# Altium schematic sheet Power Converter 12V as TO-3 replacement.SchDoc("Power Converter 12V as TO-3 replacement")
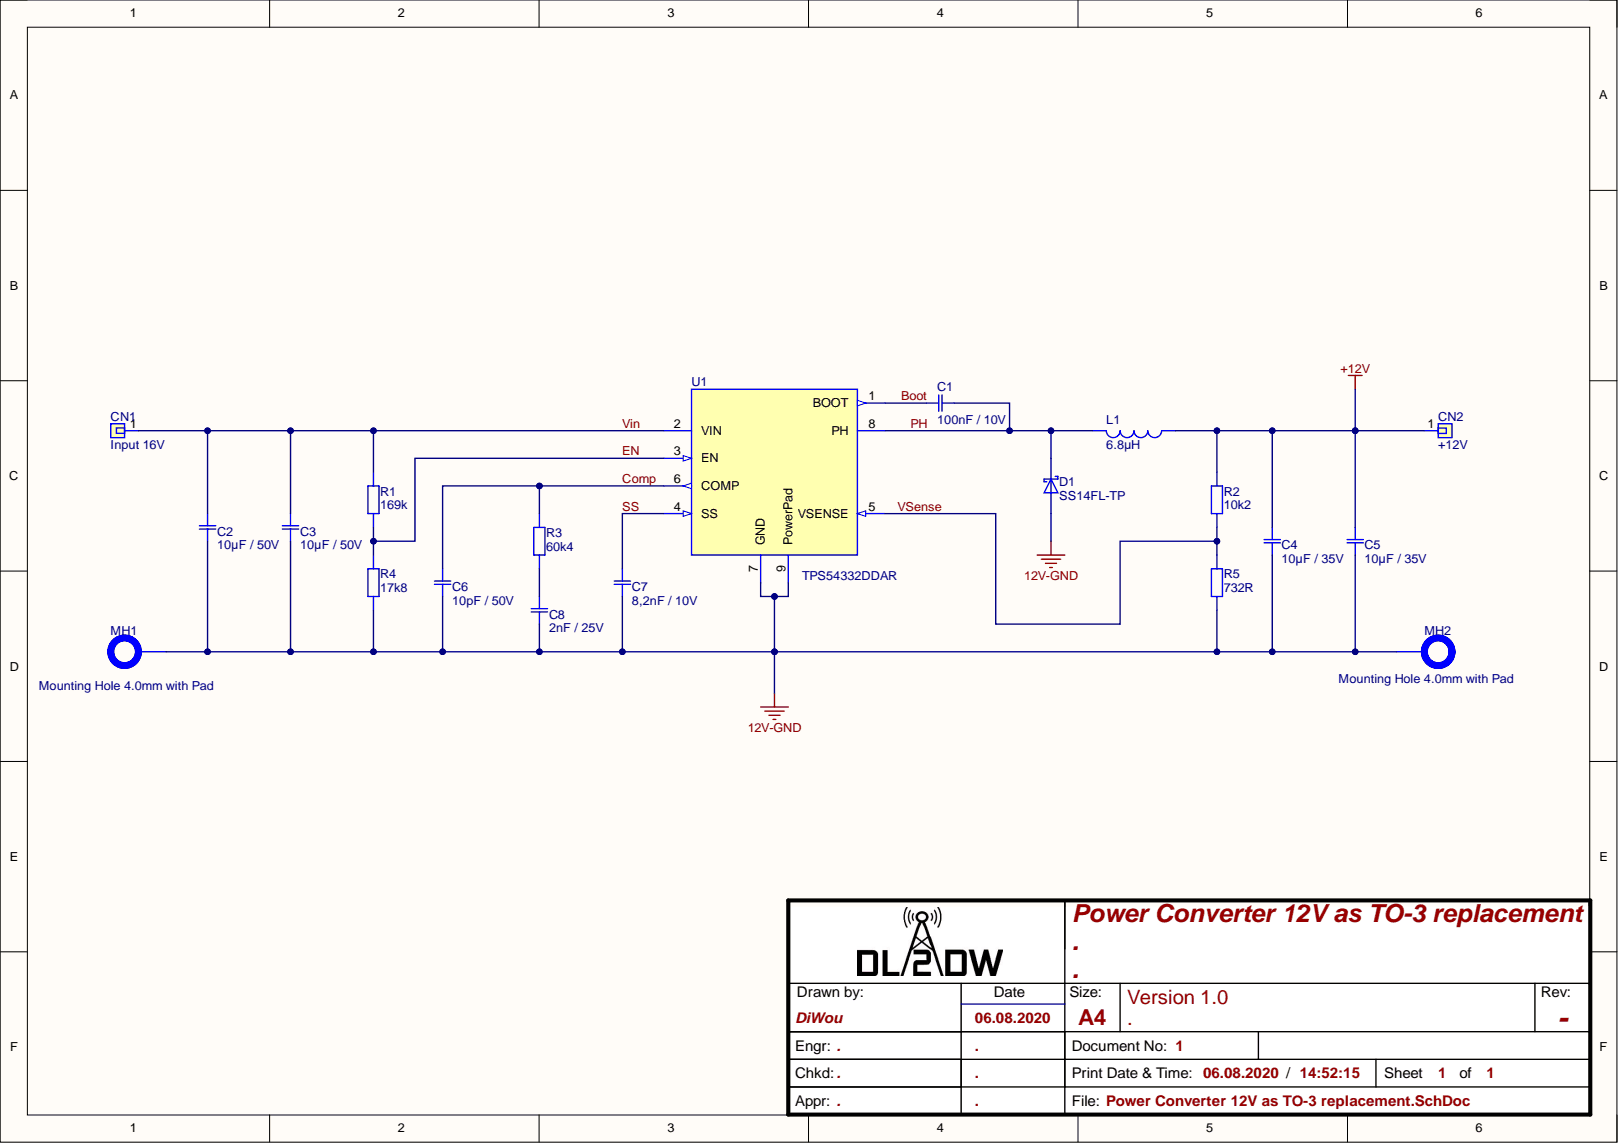
[diagram p0: Power Converter 12V as TO-3 replacement.SchDoc]

## Parts
C1  100nF / 10V  Capacitance: 100nF  [CAP CER SMD 0402 100nF 10V Murata GRM155R71A104KA01D]
C2  10µF / 50V  [CAP SMD 10µF 50V 1210 TDK C3225X7R1H106M250AC]
C3  10µF / 50V  Voltage Rating: 50V  [CAP SMD 10µF 50V 1210 TDK C3225X7R1H106M250AC]
C4  10µF / 35V  [CAP SMD 10µF 50V 1210 TDK C3216X6S1V106K160AC]
C5  10µF / 35V  Voltage Rating: 35V  [CAP SMD 10µF 50V 1210 TDK C3216X6S1V106K160AC]
C6  10pF / 50V  Capacitance: 10pF  [CAP CER SMD 0805 10pF 50V Samsung CL21C100JBANNNC]
C7  8,2nF / 10V  [CAP CER SMD 0201 8,2nF 10V Murata GRM033R71A822KA01D]
C8  2nF / 25V  Capacitance: 2nF  [CAP CER SMD 0805 2nF 25V Kemet C0805C202J3GACTU]
CN1  Input 16V  [Printed Circuit Pin 1.02mm 3125-2-00-80-00-00-08-0]
CN2  +12V  [Printed Circuit Pin 1.02mm 3125-2-00-80-00-00-08-0]
D1  SS14FL-TP  [SS14FL]
L1  6.8µH  [Shielded Drum Core 6.8µH TDK B82464G4682M]
MH1  Mounting Hole 4.0mm with Pad  [Mounting Hole 4mm with Pad]  (no BOM)
MH2  Mounting Hole 4.0mm with Pad  [Mounting Hole 4mm with Pad]  (no BOM)
R1  169k  [RES SMD 0402 169k Vishay CRCW0402169KFKED]
R2  10k2  [RES SMD 0402 10k2 Vishay CRCW040210K2FKED]
R3  60k4  [RES SMD 0402 60k4 Vishay CRCW040260K4FKED]
R4  17k8  Voltage Rating: 50V  [RES SMD 0402 17k8 Vishay CRCW040217K8FKED]
R5  732R  [RES SMD 0402 732R Vishay CRCW0402732RFKED]
U1  TPS54332DDAR  [DC-DC Converter (Buck) TPS54332DDAR SO-Power Pad]

## Nets
12V-GND: C2.1 C3.1 C4.1 C4.2 C5.1 C5.2 C6.1 C7.1 C8.2 CN2.1 D1.2 L1.2 MH1.1 MH2.1 R2.2 R4.1 R5.1 U1.7(GND) U1.9(PowerPad)
Boot: C1.1 U1.1(BOOT)
Comp: C6.2 R3.2 U1.6(COMP)
EN: R1.1 R4.2 U1.3(EN)
PH: C1.2 D1.1 L1.1 U1.8(PH)
SS: C7.2 U1.4(SS)
Vin: C2.2 C3.2 CN1.1 R1.2 U1.2(VIN)
VSense: R2.1 R5.2 U1.5(VSENSE)
NetC8_1: C8.1 R3.1

## Library data of the parts
C1 = CMP-Capacitors-Ceramic_Capacitors-00034-1: CAP CER 0.1UF 10V X7R 0402; Dissipation Factor: 0.035%; Tolerance: 10%; Case Code (Imperial): 0402; Case Code (Metric): 1005; Case/Package: 0402; Component Kind: Standard; Depth: 0.5mm; Dielectric: X7R; Height: 0.5mm; Lead Free: Lead Free; Length: 1mm; Library Name: PCB-Tech Cloud; Max Operating Temperature: 125°C; Min Operating Temperature: -55°C; Mount: Surface Mount; Number of Pins: 2; Package Quantity: 1; Packaging: Tape and Reel; Radiation Hardening: No; REACH SVHC: No SVHC; Termination: SMD/SMT; Thickness: 0.022inch; Voltage: 10V; Voltage Rating: 10V; Voltage Rating (DC): 10V; Width: 0.02inch
C2 C3 = CMP-Capacitors-Ceramic_Capacitors-00030-1: TDK - C3225X7R1H106M250AC - C3225X7R1H106MT000E; Capacitance: 10uF; Dielectric: X7R; Tolerance: 20%; Case Code (Imperial): 1210; Case Code (Metric): 3225; Case/Package: 1210; Component Kind: Standard; Dielectric Material: Ceramic; Height: 2.5mm; Lead Free: Lead Free; Length: 0.126inch; Library Name: PCB-Tech Cloud; Max Operating Temperature: 125°C; Min Operating Temperature: -55°C; Mount: Surface Mount; Package Quantity: 1000; Packaging: Tape and Reel; REACH SVHC: No SVHC; Termination: SMD/SMT; Thickness: 0.11inch; Voltage Rating (DC): 50V; Weight: 0.000564oz; Width: 0.098inch
  C2: Voltage Rating: 50V
C4 C5 = CMP-Capacitors-Ceramic_Capacitors-00035-1: Cap Ceramic 10uF 35V X6S 10% SMD 1206 105°C Punched Paper T/R; Capacitance: 10uF; Case Code (Imperial): 1206; Case Code (Metric): 3216; Case/Package: 1206; Component Kind: Standard; Dielectric: X6S; Dielectric Material: Ceramic; Height: 1.6mm; Lead Free: Lead Free; Length: 0.126inch; Library Name: PCB-Tech Cloud; Max Operating Temperature: 105°C; Min Operating Temperature: -55°C; Mount: Surface Mount; Package Quantity: 2000; Packaging: Tape and Reel; Termination: SMD/SMT; Thickness: 0.071inch; Tolerance: 10%; Voltage Rating (DC): 35V; Weight: 0.000571oz; Width: 0.063inch
  C4: Voltage Rating: 35V
C6 = CMP-Capacitors-Ceramic_Capacitors-00031-1: Cap Ceramic 10pF 50VDC C0G 5% SMD 0805 Paper T/R; Case Code (Imperial): 0805; Case Code (Metric): 2012; Case/Package: 0805; Component Kind: Standard; Contact Plating: Tin; Depth: 1.25mm; Dielectric: C0G; Height: 0.65mm; Length: 2mm; Library Name: PCB-Tech Cloud; Max Operating Temperature: 125°C; Min Operating Temperature: -55°C; Mount: Surface Mount; Package Quantity: 1; Packaging: Tape and Reel; Radiation Hardening: No; Thickness: 0.03inch; Tolerance: 5%; Voltage Rating: 50V; Width: 0.049inch
C7 = CMP-Capacitors-Ceramic_Capacitors-00033-1: Multilayer Ceramic Capacitors MLCC - SMD/SMT 0.0082uF 10volts 10%; Capacitance: 8.2nF; Dielectric: X7R; Case Code (Imperial): 0201; Case Code (Metric): 0603; Case/Package: 0201; Component Kind: Standard; Depth: 0.3mm; Height: 0.3mm; Length: 0.6mm; Library Name: PCB-Tech Cloud; Max Operating Temperature: 125°C; Min Operating Temperature: -55°C; Mount: Surface Mount; Package Quantity: 15000; Packaging: Tape and Reel; Radiation Hardening: No; Termination: SMD/SMT; Thickness: 0.013inch; Tolerance: 10%; Voltage Rating: 10V; Voltage Rating (DC): 10V; Width: 0.012inch
C8 = CMP-Capacitors-Ceramic_Capacitors-00032-1: Multilayer Ceramic Capacitors MLCC - SMD/SMT 25volts 2000pF C0G 5%; Dissipation Factor: 0.1%; Case Code (Imperial): 0805; Case Code (Metric): 2012; Case/Package: 0805; Component Kind: Standard; Depth: 1.25mm; Dielectric: C0G; Dielectric Material: Ceramic; Height: 0.78mm; Lead Free: Lead Free; Lead Pitch: 0.75mm; Length: 2mm; Library Name: PCB-Tech Cloud; Max Operating Temperature: 125°C; Min Operating Temperature: -55°C; Mount: Surface Mount; Package Quantity: 4000; Packaging: Tape and Reel; REACH SVHC: No SVHC; Termination: SMD/SMT; Thickness: 0.035inch; Tolerance: 5%; Voltage Rating: 25V; Voltage Rating (DC): 25V; Width: 1.2mm
CN1 CN2 = CMP-Mechanicals-00020-1: CONN PC PIN CIRC 0.040DIA TIN; Board Thickness: 0.062inch; Flange Diameter: 0.071inch; Gender: Male; Hole Diameter: 1.09mm; Insulation: Non-Insulated; Mounting Hole Diameter: 0.043inch; Outside Diameter: 1.8mm; Component Kind: Standard; Contact Material: Brass; Contact Plating: Tin; Length: 0.402inch; Library Name: PCB-Tech Cloud; Material: Brass; Mount: Through Hole; Package Quantity: 500; Packaging: Bulk; Plating: Tin; Termination: PC Pin
D1 = CMP-Diodes-00014-2: DIODE SCHOTTKY 40V 1A DO221AC; Average Rectified Current: 1A; Component Kind: Standard; Library Name: PCB-Tech Cloud; Max Reverse Voltage (DC): 40V; Mount: Surface Mount; Packaging: Tape and Reel
L1 = CMP-Inductors-00013-1: Inductor Power Shielded Wirewound 6.8uH 20% 100KHz Ferrite 4.3A 0.02Ohm DCR Automotive T/R; Current Rating: 2A; DC Resistance (DCR): 20mR; Saturation Current: 4.6A; Self Resonant Frequency: 28MHz; Case/Package: Nonstandard; Component Kind: Standard; Composition: Wirewound; Core Material: Ferrite; DC Current: 4.3A; Depth: 10.4mm; Height: 4.8mm; Height - Seated (Max): 0.189inch; Inductance: 6.8uH; Inductance Tolerance: 20%; Lead Free: Lead Free; Length: 10.4mm; Library Name: PCB-Tech Cloud; Max DC Current: 4.3A; Max Operating Temperature: 150°C; Military Standard: Not; Min Operating Temperature: -55°C; Mount: Surface Mount; Package Quantity: 750; Packaging: Tape and Reel; Radiation Hardening: No; Ratings: AEC-Q200; REACH SVHC: No SVHC; RMS Current (Irms): 4.3A; Shielding: Shielded; Termination: SMD/SMT; Test Frequency: 100kHz; Tolerance: 20%; Weight: 0.070548oz; Width: 10.4mm
MH1 MH2 = CMP-Mechanicals-00019-2: Description: Mounting Hole 4.0mm with Pad; Library Name: PCB-Tech Cloud
R1 = CMP-Resistors-00008-1: VISHAY - CRCW0402169KFKED - RES, THICK FILM, 169K, 1%, 0.063W, 0402, REEL; Max Power Dissipation: 63mW; Resistance: 169kR; Temperature Coefficient: 100ppm/°C; Voltage Rating: 50V; Case Code (Imperial): 0402; Case Code (Metric): 1005; Case/Package: 0402; Component Kind: Standard; Composition: Thick Film; Contact Plating: Tin; Depth: 0.5mm; Features: Automotive AEC-Q200; Height: 0.35mm; Lead Free: Lead Free; Length: 1mm; Library Name: PCB-Tech Cloud; Max Operating Temperature: 155°C; Military Standard: Not; Min Operating Temperature: -55°C; Mount: Surface Mount; Number of Pins: 2; Number of Terminations: 2; Package Quantity: 10; Packaging: Tape and Reel; Power Rating: 63mW; Radiation Hardening: No; REACH SVHC: Unknown; Termination: SMD/SMT; Tolerance: 1%; Voltage Rating (DC): 50V; Weight: 2.3E-05oz; Width: 0.02inch
R2 = CMP-Resistors-00024-1: VISHAY         CRCW040210K2FKED.            Surface Mount Chip Resistor, Thick Film, AEC-Q200 CRCW Series, 10.2 kohm, 63 mW,  1%, 50 V; Max Power Dissipation: 63mW; Resistance: 10.2kR; Temperature Coefficient: 100ppm/°C; Voltage Rating: 50V; Case Code (Imperial): 0402; Case Code (Metric): 1005; Case/Package: 0402; Component Kind: Standard; Composition: Thick Film; Contact Plating: Tin; Depth: 0.5mm; Features: Automotive AEC-Q200; Height: 0.35mm; Length: 1mm; Library Name: PCB-Tech Cloud; Max Operating Temperature: 155°C; Military Standard: Not; Min Operating Temperature: -55°C; Mount: Surface Mount; Number of Pins: 2; Number of Terminations: 2; Package Quantity: 10; Packaging: Tape and Reel; Power Rating: 63mW; Radiation Hardening: No; REACH SVHC: Unknown; Termination: SMD/SMT; Tolerance: 1%; Weight: 2.3E-05oz; Width: 0.5mm
R3 = CMP-Resistors-00010-1: VISHAY - CRCW040260K4FKED - SMD Chip Resistor, 0402 [1005 Metric], 60.4 kohm, CRCW e3 Series, 50 V, Thick Film, 63 mW; Max Power Dissipation: 63mW; Resistance: 60.4kR; Temperature Coefficient: 100ppm/°C; Tolerance: 1%; Voltage Rating: 50V; Case Code (Imperial): 0402; Case Code (Metric): 1005; Case/Package: 0402; Component Kind: Standard; Composition: Thick Film; Contact Plating: Tin; Depth: 0.5mm; Features: Automotive AEC-Q200; Height: 0.35mm; Lead Free: Lead Free; Length: 1mm; Library Name: PCB-Tech Cloud; Max Operating Temperature: 155°C; Military Standard: Not; Min Operating Temperature: -55°C; Number of Terminations: 2; Package Quantity: 10000; Packaging: Tape and Reel; Power Rating: 63mW; Radiation Hardening: No; REACH SVHC: Unknown; Termination: SMD/SMT; Voltage Rating (DC): 50V; Weight: 2.3E-05oz; Width: 0.02inch
R4 = CMP-Resistors-00009-1: VISHAY - CRCW040217K8FKED - RES, THICK FILM, 17K8, 1%, 0.063W, 0402; Max Power Dissipation: 63mW; Resistance: 17.8kR; Temperature Coefficient: 100ppm/°C; Case Code (Imperial): 0402; Case Code (Metric): 1005; Case/Package: 0402; Component Kind: Standard; Composition: Thick Film; Contact Plating: Tin; Depth: 0.5mm; Features: Automotive AEC-Q200; Height: 0.016inch; Lead Free: Lead Free; Length: 1mm; Library Name: PCB-Tech Cloud; Max Operating Temperature: 155°C; Military Standard: Not; Min Operating Temperature: -55°C; Number of Pins: 2; Number of Terminations: 2; Package Quantity: 10; Packaging: Tape and Reel; Power Rating: 63mW; Radiation Hardening: No; REACH SVHC: Unknown; Termination: SMD/SMT; Tolerance: 1%; Voltage Rating (DC): 50V; Weight: 2.3E-05oz; Width: 0.02inch
R5 = CMP-Resistors-00017-1: RES, THICK FILM, 732R, 1%, 0.063W, 0402, REEL; Product Range:AEC-Q200 CRCW Series; Resistance:732ohm; Power Rating:63mW; Resistance Tolerance: 1%; Voltage Rating:50V; Resistor Case Style:0402 [1005 Metric]; Packaging:Tape & Reel ;RoHS Compliant: Yes; Max Power Dissipation: 63mW; Temperature Coefficient: 100ppm/°C; Voltage Rating: 50V; Case Code (Imperial): 0402; Case Code (Metric): 1005; Case/Package: 0402; Component Kind: Standard; Composition: Thick Film; Contact Plating: Tin; Depth: 0.5mm; Features: Automotive AEC-Q200; Height: 0.35mm; Lead Free: Lead Free; Length: 1mm; Library Name: PCB-Tech Cloud; Max Operating Temperature: 155°C; Military Standard: Not; Min Operating Temperature: -55°C; Number of Pins: 2; Number of Terminations: 2; Package Quantity: 10; Packaging: Tape and Reel; Power Rating: 63mW; Radiation Hardening: No; REACH SVHC: Unknown; Resistance: 732R; Termination: SMD/SMT; Tolerance: 1%; Voltage Rating (DC): 50V; Weight: 2.3E-05oz; Width: 0.5mm
U1 = CMP-ICs-Power_Supply-00013-5: TEXAS INSTRUMENTS - TPS54332DDAR - DC/DC CONV, BUCK, 1MHZ, SOIC-8; Max Input Voltage: 28V; Max Output Current: 3.5A; Max Output Voltage: 26.04V; Min Input Voltage: 3.5V; Min Output Voltage: 800mV; Number of Outputs: 1; Output Configuration: Positive; Output Type: Adjustable; Output Voltage: 25V; Quiescent Current: 82uA; Synchronous Rectifier: No; Component Kind: Standard; Contact Plating: Gold; Height: 1.55mm; Lead Free: Contains Lead; Length: 5mm; Library Name: PCB-Tech Cloud; Max Operating Temperature: 150°C; Min Operating Temperature: -40°C; Min Supply Voltage: 3.5V; Mount: Surface Mount; Number of Pins: 8; Operating Supply Voltage: 28V; Output Current: 3.5A; Package Quantity: 2500; Packaging: Tape and Reel; Radiation Hardening: No; REACH SVHC: No SVHC; Switching Frequency: 1MHz; Weight: 0.00249oz; Width: 4mm
